# Altium schematic sheet 0_Overview.SchDoc
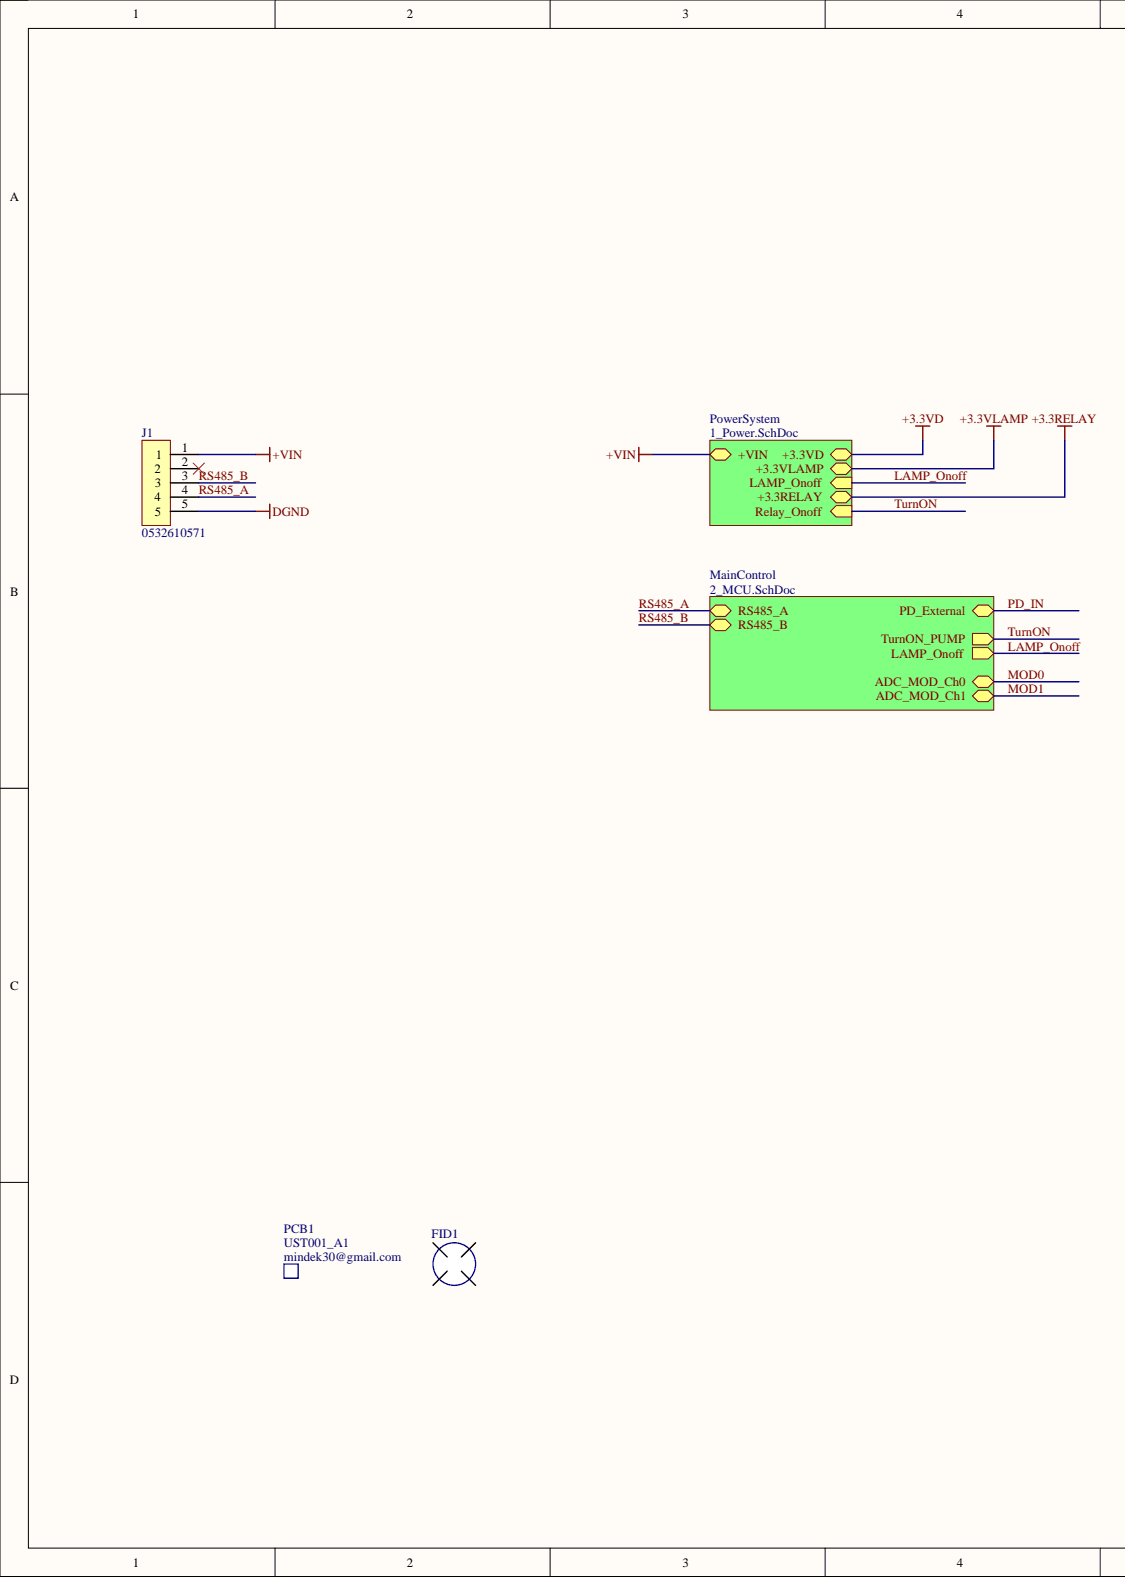
[diagram p0: 0_Overview.SchDoc, left]
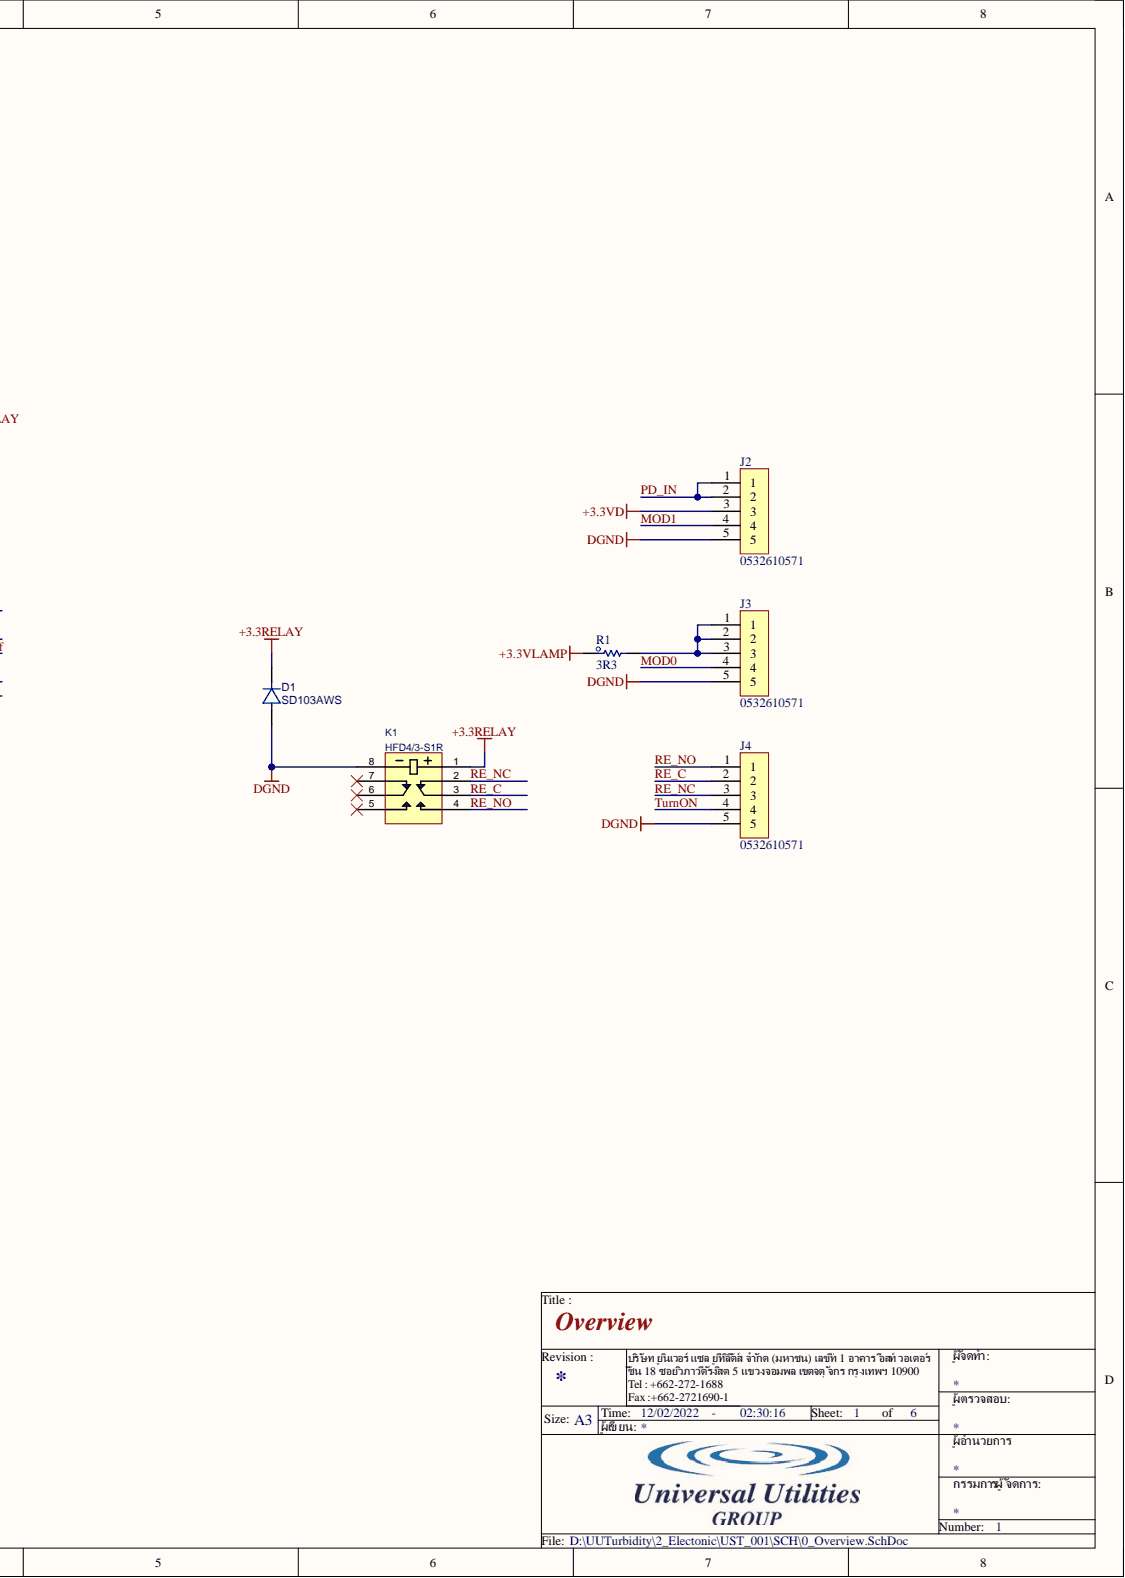
[diagram p1: 0_Overview.SchDoc, right]

## Parts
D1  SD103AWS  [SOD323]
FID1  FIDUCIAL  [FID]  (value not drawn)  (no BOM)
J1  0532610571  [MOLEX_532610571]
J2  0532610571  [MOLEX_532610571]
J3  0532610571  [MOLEX_532610571]
J4  0532610571  [MOLEX_532610571]
K1  HFD4/3-S1R  [RELAY_HFD4/12-SR]
PCB1  UST001_A1  Manufacturer: mindek30@gmail.com  (mechanical)
R1  3R3  [R_2512]

## Nets
+3.3RELAY: D1.1 K1.1
+3.3VD: J2.3
+3.3VLAMP: R1.1
+VIN: J1.1
DGND: D1.2 J1.5 J2.5 J3.5 J4.5 K1.8
MOD0: J3.4
MOD1: J2.4
PD_IN: J2.1 J2.2
RE_C: J4.2 K1.3
RE_NC: J4.3 K1.2
RE_NO: J4.1 K1.4
RS485_A: J1.4
RS485_B: J1.3
TurnON: J4.4
NetJ1_2: J1.2
NetJ3_1: J3.1 J3.2 J3.3 R1.2
NetK1_5: K1.5
NetK1_6: K1.6
NetK1_7: K1.7
LAMP_Onoff:

## Library data of the parts
D1 = D_PW_SD103AWS: DIODE SCHOTTKY 40V 2A 0603; Manufacturer: Slkor(SLKORMICRO Elec.); Component Kind: Standard; PackageReference: SOD-323; PartID: C513472; PartNumber: SD103AWS; Value: SD103AWS; Library Name: UUSymbol.SchLib
FID1 = FID_FIDUCIAL: Manufacturer: mindek30@gmail.com; PartNumber: UST001_A1; Value: Non SE; Description: Fiducial; PackageReference: Non SE; PartID: A1; Value2: Non SE; Value3: Non SE; Library Name: UUSymbol.SchLib
J1-J4 = CON_5P_532610571: CONN HEADER SMD R/A 5POS 1.25MM; Manufacturer: Molex; Value2: AFC12-S05DCC-00; Component Kind: Standard; PackageReference: 5P; PartID: WM7623CT-ND; PartNumber: 0532610571; Value: 0532610571; Library Name: UUSymbol.SchLib
K1 = SW_RELAY_HFD4/12-SR: Telecom Non Latching 3VDC DPDT SMD Relays RoHS; Manufacturer: Xiamen Hongfa Electroacoustic; Component Kind: Standard; PartID: C143232; PartNumber: HFD4/3-S1R; Value: HFD4/3-S1R; Library Name: UUSymbol.SchLib
PCB1 = PCB_Bare: BARE PCB; PackageReference: Non SE; PartID: A1; PartNumber: UST001_A1; Value: UST001_A1; Value3: UST001_A1; Library Name: UUSymbol.SchLib
R1 = R_Resister: RES SMD 3.3 OHM 5% 3W 2512; Manufacturer: Vishay; PartNumber: 35223R3JT; Component Kind: Standard; PackageReference: 2512; PartID: A121247CT-ND; Value: 3R3; Value2: 3W; Value3: 5%; Library Name: UUSymbol.SchLib
